# Altium schematic sheet Ethernet Schematic.SchDoc
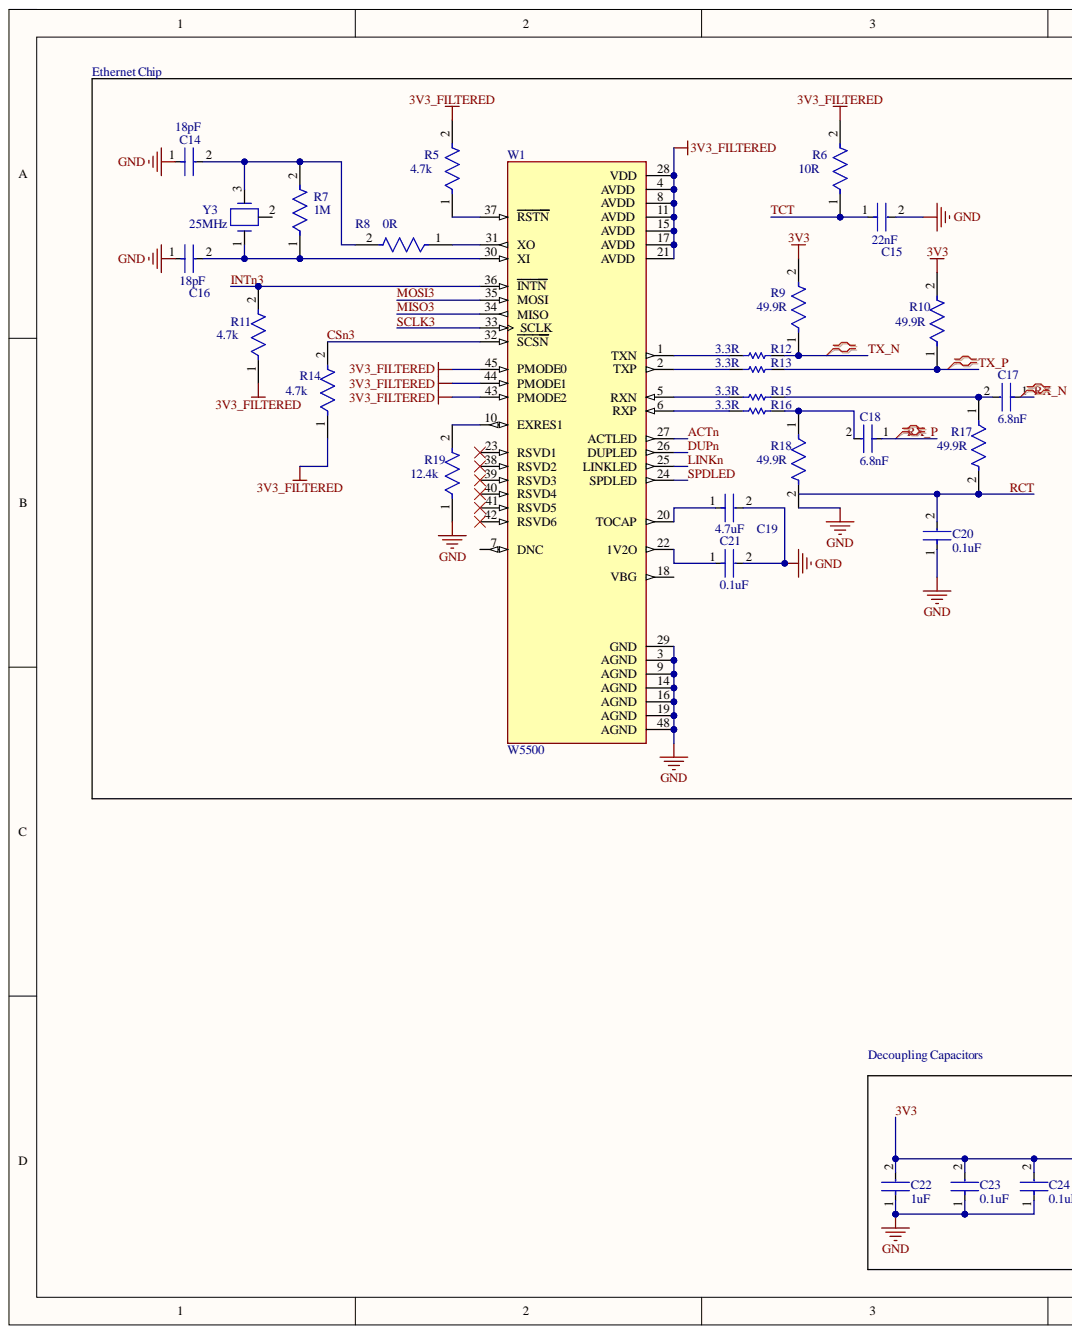
[diagram p0: Ethernet Schematic.SchDoc, left]
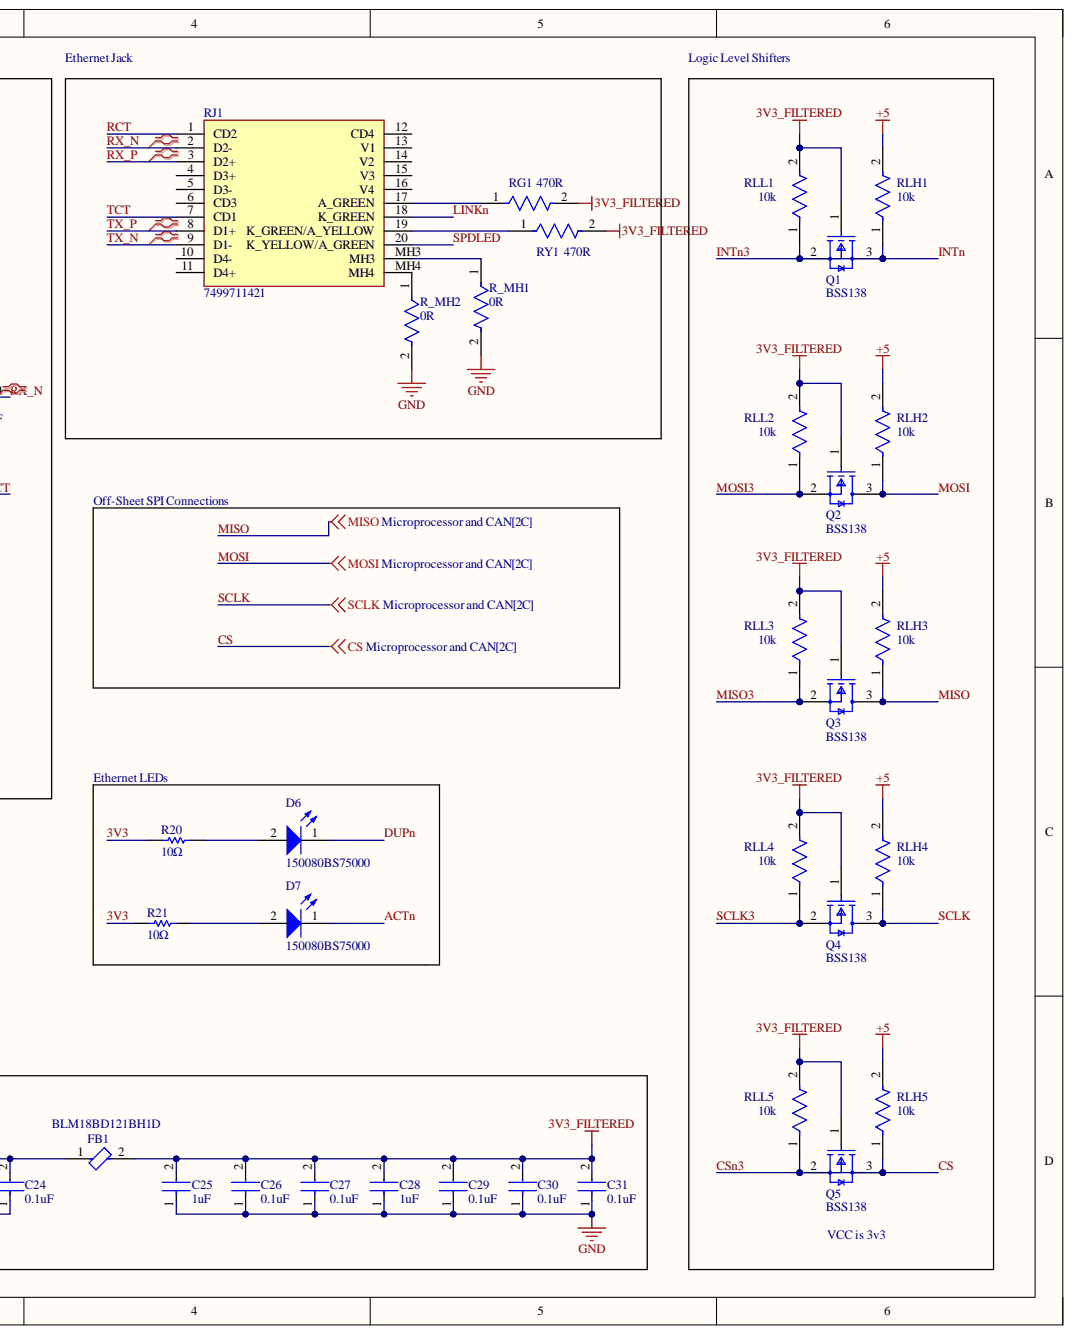
[diagram p1: Ethernet Schematic.SchDoc, right]

## Parts
C14  18pF  [FP-C0805C-DN-MFG]
C15  22nF  [FP-C0805C-DN-MFG]
C16  18pF  [FP-C0805C-DN-MFG]
C17  6.8nF  [FP-C0805C-DN-MFG]
C18  6.8nF  [FP-C0805C-DN-MFG]
C19  4.7uF  [FP-C0805C-DN-MFG]
C20  0.1uF  [FP-C0805C-DN-MFG]
C21  0.1uF  [FP-C0805C-DN-MFG]
C22  1uF  [FP-C0805C-DN-MFG]
C23  0.1uF  [FP-C0805C-DN-MFG]
C24  0.1uF  [FP-C0805C-DN-MFG]
C25  1uF  [FP-C0805C-DN-MFG]
C26  0.1uF  [FP-C0805C-DN-MFG]
C27  0.1uF  [FP-C0805C-DN-MFG]
C28  1uF  [FP-C0805C-DN-MFG]
C29  0.1uF  [FP-C0805C-DN-MFG]
C30  0.1uF  [FP-C0805C-DN-MFG]
C31  0.1uF  [FP-C0805C-DN-MFG]
D6  150080BS75000  [WL-SMCW_0805_150080xx75000]
D7  150080BS75000  [WL-SMCW_0805_150080xx75000]
FB1  BLM18BD121BH1D  [FP-BLM18-0_15-t0_8-MFG]
Q1  BSS138  [FP-318-08-IPC_B]
Q2  BSS138  [FP-318-08-IPC_B]
Q3  BSS138  [FP-318-08-IPC_B]
Q4  BSS138  [FP-318-08-IPC_B]
Q5  BSS138  [FP-318-08-IPC_B]
R5  4.7k  [FP-CPF0805-MFG]
R6  10R  [FP-CPF0805-MFG]
R7  1M  [FP-CPF0805-MFG]
R8  0R  [FP-CPF0805-MFG]
R9  49.9R  [FP-CPF0805-MFG]
R10  49.9R  [FP-CPF0805-MFG]
R11  4.7k  [FP-CPF0805-MFG]
R12  3.3R  [RESC3216X70X50LL05T20]
R13  3.3R  [RESC3216X70X50LL05T20]
R14  4.7k  [FP-CPF0805-MFG]
R15  3.3R  [RESC3216X70X50LL05T20]
R16  3.3R  [RESC3216X70X50LL05T20]
R17  49.9R  [FP-CPF0805-MFG]
R18  49.9R  [FP-CPF0805-MFG]
R19  12.4k  [FP-CPF0805-MFG]
R20  10Ω  [RESC2013X50X30NL20T20]
R21  10Ω  [RESC2013X50X30NL20T20]
R_MH1  0R  [FP-CPF0805-MFG]
R_MH2  0R  [FP-CPF0805-MFG]
RG1  470R  [FP-CPF0805-MFG]
RJ1  7499711421  [7499711421]
RLH1  10k  [FP-CPF0805-MFG]
RLH2  10k  [FP-CPF0805-MFG]
RLH3  10k  [FP-CPF0805-MFG]
RLH4  10k  [FP-CPF0805-MFG]
RLH5  10k  [FP-CPF0805-MFG]
RLL1  10k  [FP-CPF0805-MFG]
RLL2  10k  [FP-CPF0805-MFG]
RLL3  10k  [FP-CPF0805-MFG]
RLL4  10k  [FP-CPF0805-MFG]
RLL5  10k  [FP-CPF0805-MFG]
RY1  470R  [FP-CPF0805-MFG]
W1  W5500  [QFP50P900X900X160-48N]
Y3  25MHz  [ECS-4X-3IL]

## Nets
3V3_FILTERED: C25.2 C26.2 C27.2 C28.2 C29.2 C30.2 C31.2 FB1.2 Q1.1 Q2.1 Q3.1 Q4.1 Q5.1 R5.2 R6.2 R11.1 R14.1 RG1.2 RLL1.2 RLL2.2 RLL3.2 RLL4.2 RLL5.2 RY1.2 W1.4(AVDD) W1.8(AVDD) W1.11(AVDD) W1.15(AVDD) W1.17(AVDD) W1.21(AVDD) W1.28(VDD) W1.43(PMODE2) W1.44(PMODE1) W1.45(PMODE0)
+5: RLH1.2 RLH2.2 RLH3.2 RLH4.2 RLH5.2
GND: C14.1 C15.2 C16.1 C19.2 C20.1 C21.2 C22.1 C23.1 C24.1 C25.1 C26.1 C27.1 C28.1 C29.1 C30.1 C31.1 R18.2 R19.1 R_MH1.2 R_MH2.2 W1.3(AGND) W1.9(AGND) W1.14(AGND) W1.16(AGND) W1.19(AGND) W1.29(GND) W1.48(AGND)
3V3: C22.2 C23.2 C24.2 FB1.1 R9.2 R10.2 R20.1 R21.1
ACTn: D7.1 W1.27(ACTLED)
CS: Q5.2 Q5.3 R14.2 RLH5.1 RLL5.1 W1.32(SCSN)
DUPn: D6.1 W1.26(DUPLED)
INTn: Q1.2 Q1.3 R11.2 RLH1.1 RLL1.1 W1.36(INTN)
LINKn: RJ1.18(K_GREEN) W1.25(LINKLED)
MISO: Q3.3 RLH3.1
MISO3: Q3.2 RLL3.1 W1.34(MISO)
MOSI: Q2.3 RLH2.1
MOSI3: Q2.2 RLL2.1 W1.35(MOSI)
RCT: C20.2 R17.2 RJ1.1(CD2)
RX_N: C17.1 RJ1.2(D2-)
RX_P: C18.1 RJ1.3(D2+)
SCLK: Q4.3 RLH4.1
SCLK3: Q4.2 RLL4.1 W1.33(SCLK)
SPDLED: RJ1.20(K_YELLOW/A_GREEN) W1.24(SPDLED)
TCT: C15.1 R6.1 RJ1.7(CD1)
TX_N: R9.1 R12.2 RJ1.9(D1-)
TX_P: R10.1 R13.2 RJ1.8(D1+)
NetC14_2: C14.2 R7.2 R8.2 Y3.3
NetC16_2: C16.2 R7.1 W1.30(XI) Y3.1
NetC17_2: C17.2 R15.2 R17.1
NetC18_2: C18.2 R16.2 R18.1
NetC19_1: C19.1 W1.20(TOCAP)
NetC21_1: C21.1 W1.22(1V2O)
NetD6_2: D6.2 R20.2
NetD7_2: D7.2 R21.2
NetR5_1: R5.1 W1.37(RSTN)
NetR8_1: R8.1 W1.31(XO)
NetR12_1: R12.1 W1.1(TXN)
NetR13_1: R13.1 W1.2(TXP)
NetR15_1: R15.1 W1.5(RXN)
NetR16_1: R16.1 W1.6(RXP)
NetR19_2: R19.2 W1.10(EXRES1)
NetR_MH1_1: R_MH1.1 RJ1.MH3
NetR_MH2_1: R_MH2.1 RJ1.MH4
NetRG1_1: RG1.1 RJ1.17(A_GREEN)
NetRJ1_19: RJ1.19(K_GREEN/A_YELLOW) RY1.1

Not connected: RJ1 4(D3+) 5(D3-) 6(CD3) 10(D4-) 11(D4+) 12(CD4) 13(V1) 14(V2) 15(V3) 16(V4); W1 7(DNC) 18(VBG) 23(RSVD1) 38(RSVD2) 39(RSVD3) 40(RSVD4) 41(RSVD5) 42(RSVD6); Y3 2

## Library data of the parts
C14-C31 = CMP-1036-01133-2: CAP CER 18PF 25V 20% NP0 0805; Manufacturer: KEMET; Manufacturer Part Number: C0805C180M3GACTU; Material: Ceramic; Temperature Characteristic: C0G; Temperature Coefficient: 30ppm/°C; Tolerance: 0.2; Automotive: No; Capacitance: 18 pF; Category: Cap; Component Kind: Standard; ComponentLink2Description: Datasheet; ComponentLink2URL: https://content.kemet.com/datasheets/KEM_C1003_C0G_SMD.pdf; Device Class L1: Passive Components; Device Class L2: Capacitors; Device Class L3: Ceramic Capacitors; Digikey Description: CAP CER 18PF 25V 20% NP0 0805; Height: 0.88mm; Ipc Land Pattern Name: CAPC200125X78; Lead Free: Yes; Library Name: Altium Content Vault; Mouser Description: Multilayer Ceramic Capacitors MLCC - SMD/SMT 25volts 18pF C0G 20%; Octopart: https://octopart.com/c0805c180m3gactu-kemet-17137232; Package: C0805C-DN; RoHS: TRUE; Temperature: -55°C to +125°C; Temperature Range High: +125°C; Temperature Range Low: -55°C; Value: 18 pF; Voltage Rating: 25 V
D6 D7 = CMP-1426-00008-7: Led, Blue, 470 Nm, 3.2 V, 30 Ma, 145 Mcd Rohs Compliant: Yes; Dominant Wavelength: 470nm; Forward Current: 100mA; Forward Voltage: 3.2V; Lens Style: Clear, Rectangular; Luminous Intensity: 145mcd; Max Junction Temperature (Tj): 95°C; Max Reverse Voltage (DC): 5V; Peak Wavelength: 465nm; Power Dissipation: 105mW; Test Current: 20mA; Viewing Angle: 140°; Case Code (Imperial): 0805; Case Code (Metric): 2012; Case/Package: 0805; Color: Blue; Component Kind: Standard; Height: 700µm; Lead Free: Lead Free; Length: 2mm; Library Name: Altium Content Vault; Max Operating Temperature: 85°C; Min Operating Temperature: -40°C; Mount: Surface Mount; Packaging: Tape and Reel; REACH SVHC: Unknown; Reverse Voltage: 5V; RoHS: Compliant; Wavelength: 470nm; Width: 1.25mm
FB1 = CMP-06046-002548-1: Chip Ferrite Bead, 0603, 120Ω @ 100MHz, 0.4Ω, 25%, 300mA; DC Resistance (DCR): 400mR; Number of Lines: 1; Case Code (Imperial): 0603; Case Code (Metric): 1608; Case/Package: 0603; Component Kind: Standard; Library Name: Altium Content Vault; Max Current Rating: 300mA; Max Operating Temperature: 150°C; Min Operating Temperature: -55°C; Ratings: AEC-Q200; RoHS Compliant: Yes
Q1-Q5 = CMP-07173-000101-1: MOSFET N-CH 50V 220MA SOT-23; Drain to Source Resistance: 3.5R; Element Configuration: Single; Fall Time: 9ns; Gate to Source Voltage (Vgs): 20V; Input Capacitance: 27pF; Max Power Dissipation: 360mW; Nominal Vgs: 1.3V; Number of Elements: 1; On-State Resistance: 6R; Turn-Off Delay Time: 20ns; Turn-On Delay Time: 2.5ns; Case/Package: SOT-23; Component Kind: Standard; Contact Plating: Tin; Continuous Drain Current (ID): 220mA; Current Rating: 220mA; Drain to Source Breakdown Voltage: 50V; Drain to Source Voltage (Vdss): 50V; Dual Supply Voltage: 50V; Height: 0.93mm; Lead Free: Lead Free; Length: 2.92mm; Library Name: Altium Content Vault; Max Operating Temperature: 150°C; Min Operating Temperature: -55°C; Mount: Surface Mount; Number of Pins: 3; Package Quantity: 1; Packaging: Tape and Reel; Power Dissipation: 360mW; Radiation Hardening: No; Rds On Max: 3.5R; REACH SVHC: No SVHC; Rise Time: 9ns; Termination: SMD/SMT; Threshold Voltage: 1.3V; Voltage Rating (DC): 50V; Weight: 0.03g; Width: 1.3mm
R5-R11 R14 R17-R19 R_MH1 R_MH2 RG1 RLH1-RLH5 RLL1-RLL5 RY1 = CMP-03211-011426-1: RES SMD 1.6M OHM 0.1% 1/10W 0805; Max Power Dissipation: 100mW; Number of Resistors: 1; Resistance: 1.6MR; Temperature Coefficient: 25ppm/°C; Voltage Rating: 100V; Case Code (Imperial): 0805; Case Code (Metric): 2012; Case/Package: 0805; Component Kind: Standard; ELV: Compliant; Height: 0.55mm; Length: 2mm; Library Name: Altium Content Vault; Max Operating Temperature: 155°C; Min Operating Temperature: -55°C; Mount: Surface Mount; Number of Terminations: 2; Package Quantity: 1000; Packaging: Tape and Reel; Power Rating: 100mW; Resistor Type: Thin Film; RoHS Compliant: Yes; Series: CPF, Neohm; Termination: Solder; Tolerance: 0.1%; Weight: 0.000194oz; Width: 1.25mm
R12 R13 R15 R16 = CMP-2003-00687-1: Manufacturer: Panasonic; Part Number: ERJ-8RQF3R3V; Component Kind: Standard; Library Name: Altium Content Vault
R20 R21 = CMP-2001-04915-1: Manufacturer: Vishay Dale; Part Number: CRCW080510R0FKEA; Component Kind: Standard; Library Name: Altium Content Vault
RJ1 = 7499711421: Connector; Manufacturer_Name: Wurth Elektronik; Component Kind: Standard; Datasheet Link: https://www.we-online.com/catalog/datasheet/7499711421.pdf; Height: 13.87mm; Manufacturer_Part_Number: 7499711421; Mouser Part Number: 710-7499711421; Mouser Price/Stock: https://www.mouser.co.uk/ProductDetail/Wurth-Elektronik/7499711421?qs=ljCeji4nMDkSFYeFRPO9OA%3D%3D
W1 = W5500: Ethernet Controller 10/100 Base-T/TX PHY SPI Interface 48-LQFP (7x7); MF: WIZnet; Availability: In Stock; Check_prices: https://www.snapeda.com/parts/W5500/WIZnet/view-part/?ref=eda; Component Kind: Standard; Library Name: W5500.IntLib; MP: W5500; Package: None; Price: None; Purchase-URL: https://pricing.snapeda.com/search/part/W5500/?ref=eda; SnapEDA_Link: https://www.snapeda.com/parts/W5500/WIZnet/view-part/?ref=snap
Y3 = CMP-1669-00002-2: CRYSTAL 8.00 MHZ 20PF 49US; Manufacturer: ECS; PartNumber: ECS-80-20-4X; Component Kind: Standard; ComponentLink1Description: Datasheet; ComponentLink1URL: http://www.ecsxtal.com/store/pdf/hc-49usx.pdf; Library Name: Altium Content Vault; PackageReference: 4X-3IL
